# Altium schematic sheet CPUSchDoc.SchDoc
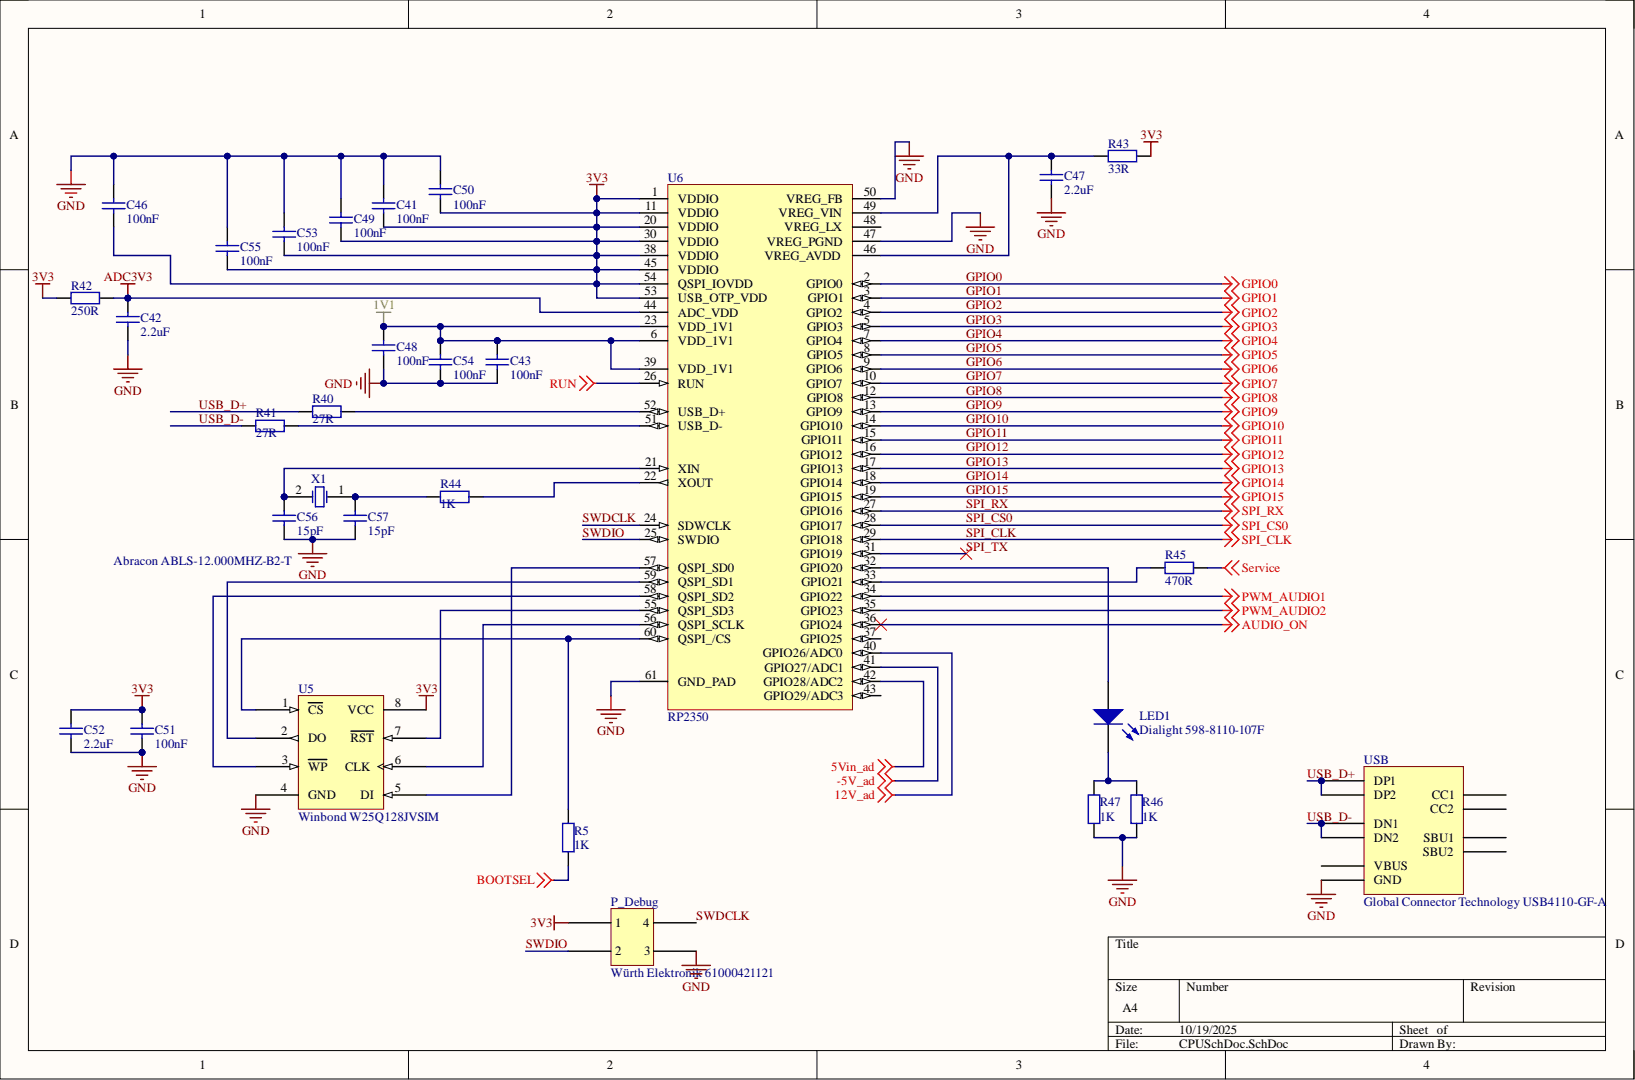
[diagram p0: CPUSchDoc.SchDoc]

## Parts
C41  100nF  [PCB-god88euyegdvhk3bnqo6-1]
C42  2.2uF  [PCB-god88euyegdvhk3bnqo6-1]
C43  100nF  [PCB-god88euyegdvhk3bnqo6-1]
C46  100nF  [PCB-god88euyegdvhk3bnqo6-1]
C47  2.2uF  [PCB-god88euyegdvhk3bnqo6-1]
C48  100nF  [PCB-god88euyegdvhk3bnqo6-1]
C49  100nF  [PCB-god88euyegdvhk3bnqo6-1]
C50  100nF  [PCB-god88euyegdvhk3bnqo6-1]
C51  100nF  [PCB-god88euyegdvhk3bnqo6-1]
C52  2.2uF  [PCB-god88euyegdvhk3bnqo6-1]
C53  100nF  [PCB-god88euyegdvhk3bnqo6-1]
C54  100nF  [PCB-god88euyegdvhk3bnqo6-1]
C55  100nF  [PCB-god88euyegdvhk3bnqo6-1]
C56  15pF  [PCB-god88euyegdvhk3bnqo6-1]
C57  15pF  [PCB-god88euyegdvhk3bnqo6-1]
LED1  Dialight 598-8110-107F  [PCB-9ifma0oh53wy532w7hco-2]
P_Debug  Würth Elektronik 61000421121  Manufacturer Part Number: 61000421121  [PCB-njs2c2o8kucklm1en4i3-1]
R5  1K  [PCB-7wrvqjq7seaxrusqyahf-1]
R40  27R  [PCB-7wrvqjq7seaxrusqyahf-1]
R41  27R  [PCB-7wrvqjq7seaxrusqyahf-1]
R42  250R  [PCB-7wrvqjq7seaxrusqyahf-1]
R43  33R  [PCB-7wrvqjq7seaxrusqyahf-1]
R44  1K  [PCB-7wrvqjq7seaxrusqyahf-1]
R45  470R  [PCB-7wrvqjq7seaxrusqyahf-1]
R46  1K  [PCB-7wrvqjq7seaxrusqyahf-1]
R47  1K  [PCB-7wrvqjq7seaxrusqyahf-1]
U5  Winbond W25Q128JVSIM  Manufacturer Part Number: W25Q128JVSIM  [PCB-wh1oml3ncb9rp3yf09m2-1]
U6  RP2350  [RP2350-Footprint-1]
USB  Global Connector Technology USB4110-GF-A  [usb4110-gf-a]
X1  Abracon ABLS-12.000MHZ-B2-T  [PCB-17bxwujfmayt9s0k9qiq-1]

## Nets
1V1: C43.2 C48.2 C54.2 U6.6(VDD_1V1) U6.23(VDD_1V1) U6.39(VDD_1V1)
3V3: C41.1 C46.1 C49.1 C50.1 C51.2 C52.2 C53.1 C55.1 P_Debug.1 R42.2 R43.1 U5.8(VCC) U6.1(VDDIO) U6.11(VDDIO) U6.20(VDDIO) U6.30(VDDIO) U6.38(VDDIO) U6.45(VDDIO) U6.53(USB_OTP_VDD) U6.54(QSPI_IOVDD)
5Vin_ad: U6.42(GPIO28/ADC2)
12V_ad: U6.40(GPIO26/ADC0)
-5V_ad: U6.41(GPIO27/ADC1)
ADC3V3: C42.2 R42.1 U6.44(ADC_VDD)
AUDIO_ON: U6.36(GPIO24)
BOOTSEL: R5.2
GND: C41.2 C42.1 C43.1 C46.2 C47.2 C48.1 C49.2 C50.2 C51.1 C52.1 C53.2 C54.1 C55.2 C56.1 C57.1 P_Debug.3 R46.2 R47.2 U5.4(GND) U6.47(VREG_PGND) U6.50(VREG_FB) U6.61(GND_PAD) USB.GND
PWM_AUDIO1: U6.34(GPIO22)
PWM_AUDIO2: U6.35(GPIO23)
RUN: U6.26(RUN)
Service: R45.1
GPIO0: U6.2(GPIO0)
GPIO1: U6.3(GPIO1)
GPIO2: U6.4(GPIO2)
GPIO3: U6.5(GPIO3)
GPIO4: U6.7(GPIO4)
GPIO5: U6.8(GPIO5)
GPIO6: U6.9(GPIO6)
GPIO7: U6.10(GPIO7)
GPIO8: U6.12(GPIO8)
GPIO9: U6.13(GPIO9)
GPIO10: U6.14(GPIO10)
GPIO11: U6.15(GPIO11)
GPIO12: U6.16(GPIO12)
GPIO13: U6.17(GPIO13)
GPIO14: U6.18(GPIO14)
GPIO15: U6.19(GPIO15)
SPI_CLK: U6.29(GPIO18)
SPI_CS0: U6.28(GPIO17)
SPI_RX: U6.27(GPIO16)
SPI_TX: U6.31(GPIO19)
SWDCLK: P_Debug.4 U6.24(SDWCLK)
SWDIO: P_Debug.2 U6.25(SWDIO)
USB_D+: R40.2 USB.DP1 USB.DP2
USB_D-: R41.2 USB.DN1 USB.DN2
NetC47_1: C47.1 R43.2 U6.46(VREG_AVDD) U6.49(VREG_VIN)
NetC56_2: C56.2 U6.21(XIN) X1.2
NetC57_2: C57.2 R44.2 X1.1
NetLED1_1: LED1.1 R46.1 R47.1
NetLED1_2: LED1.2 U6.32(GPIO20)
NetR5_1: R5.1 U5.1(CS) U6.60(QSPI_/CS)
NetR40_1: R40.1 U6.52(USB_D+)
NetR41_1: R41.1 U6.51(USB_D-)
NetR44_1: R44.1 U6.22(XOUT)
NetR45_2: R45.2 U6.33(GPIO21)
NetU5_2: U5.2(DO) U6.59(QSPI_SD1)
NetU5_3: U5.3(WP) U6.58(QSPI_SD2)
NetU5_5: U5.5(DI) U6.57(QSPI_SD0)
NetU5_6: U5.6(CLK) U6.56(QSPI_SCLK)
NetU5_7: U5.7(RST) U6.55(QSPI_SD3)

Not connected: U6 37(GPIO25) 43(GPIO29/ADC3) 48(VREG_LX); USB CC1 CC2 SBU1 SBU2 VBUS

## Library data of the parts
C41-C43 C46-C57 = CMP-ee2a246dec7f31ed-7: Capacitor; Ceramic; Cap 0.1 uF; Tol 10%; Vol-Rtg 25 VDC; SMT; 0805; X7R; Tape & Reel; Component Kind: Standard; Library Name: My Personal Space
LED1 = CMP-1071566-10: 598-8110-107F, Dialight, SMD LED 0805 Package, Red Single color SOLD IN INCREMENTS OF 4000. (REEL); Case/Package (SI): 2012; Forward Voltage: 2.20 V; Lead-Free Status: Lead Free; Lens Type: Non-Diffused; Luminous Intensity: 40.0 mcd; Viewing Angle: 140 °; Wavelength: 635 nm, 642 nm; Case/Package: 0805; Color: Red; Component Kind: Standard; Lens Color: Red; Library Name: My Personal Space; Manufacturer Part Number: 598-8110-107F; Mounting Style: Surface Mount, Vertical; Number of Pins: 2; Octopart URL: https://octopart.com/598-8110-107f-dialight-868569; OctopartID: bcc375c4d97c6304; Operating Temperature: 85.0 °C (max); Packaging: Cut Tape (CT); REACH SVHC Compliance: No SVHC; RoHS: Compliant; Size-Height: 700 µm; Size-Length: 2.00 mm; Size-Width: 1.25 mm
P_Debug = CMP-a65a6ea5d6be799d-1: Board-To-Board Connector, WR-PHD Series, Surface Mount, Header, 4, 2.54 mm, Gold Plated Contacts; Gender: Male; Number of Contacts: 4; Component Kind: Standard; Contact Material: Copper; Contact Plating: Gold; Library Name: My Personal Space; Mounting Style: Surface Mount, Vertical; Octopart URL: http://octopart.com/61000421121-w%C3%BCrth+elektronik-32855426; OctopartID: a65a6ea5d6be799d; REACH SVHC Compliance: No SVHC; RoHS: Compliant
R5 R40-R47 = CMP-3bd8f394a633e41f-2: Res Thin Film 2.0 x 1.2 mm 2K Ohm 0.1% 0.1W(1/10W) 10ppm/ C Molded SMD Paper T/R; Manufacturer Part Number: RN73C2A2K0BTD; Case/Package (SI): 2012; ELV Compliant: Compliant; Power Rating: 100 mW; Resistance: 2.00 kΩ; Voltage Rating (DC): 100 V; Case/Package: 0805; Component Kind: Standard; Library Name: My Personal Space; Lifecycle Status: Active; Mounting Style: Surface Mount; Number of Pins: 2; Octopart URL: http://octopart.com/rn73c2a2k0btdf-te+connectivity-21411981; OctopartID: 3bd8f394a633e41f; Packaging: Tape & Reel (TR); REACH SVHC Compliance: No SVHC; Resistance Tolerance: ±0.1%; RoHS: Compliant
U5 = CMP-f6a03b754ae22967-1: NOR Flash Serial (SPI, Dual SPI, Quad SPI) 3V/3.3V 128M-bit 16M x 8 6ns 8-Pin SOIC; Component Kind: Standard; Library Name: My Personal Space; Octopart URL: https://octopart.com/w25q128jvsim-winbond-81762596; OctopartID: f6a03b754ae22967
U6 = CMP-93512150-2: Raspberry PI RP2350. UNTESTED, Unnoficial homebrew outline/footprint (Sep 2024); Component Kind: Standard; Library Name: My Personal Space; Manufacturer Part Number: RP2350; OctopartID: 93512150
USB = CMP-d2c01d854ca00d07-4: Conn USB Type C RCP 16 POS 0.3mm/0.5mm Solder ST SMD 16 Terminal 1 Port T/R; Component Kind: Standard; Library Name: My Personal Space; Manufacturer Part Number: USB4110-GF-A; Octopart Url: https://octopart.com/usb4110-gf-a-global+connector+technology-105888518; OctopartID: d2c01d854ca00d07
X1 = CMP-3dd8f4d2cb48e21f-2: Crystal 12MHz ??20ppm (Tol) ??50ppm (Stability) 18pF FUND 50Ohm Automotive 2-Pin HC-49/US SMD T/R; Equivalent Series Resistance (ESR): 50.0 Ω; Frequency: 12.0 MHz; Lead-Free Status: Lead Free; Load Capacitance: 18.0 pF; Case/Package: HC-49; Component Kind: Standard; Library Name: My Personal Space; Manufacturer: Abracon; Manufacturer Part Number: ABLS-12.000MHZ-B2-T; Mounting Style: Surface Mount; Number of Pins: 2; Octopart URL: https://octopart.com/abls-12.000mhz-b2-t-abracon-54262; OctopartID: 3dd8f4d2cb48e21f; Operating Temperature: -20.0 °C to 70.0 °C; Packaging: Tape & Reel (TR), Cut Tape (CT); REACH SVHC Compliance: Contains SVHC; RoHS: Compliant; Size-Height: 4.20 mm; Size-Length: 12.7 mm
